# Altium schematic sheet P04_USB_hub.SchDoc
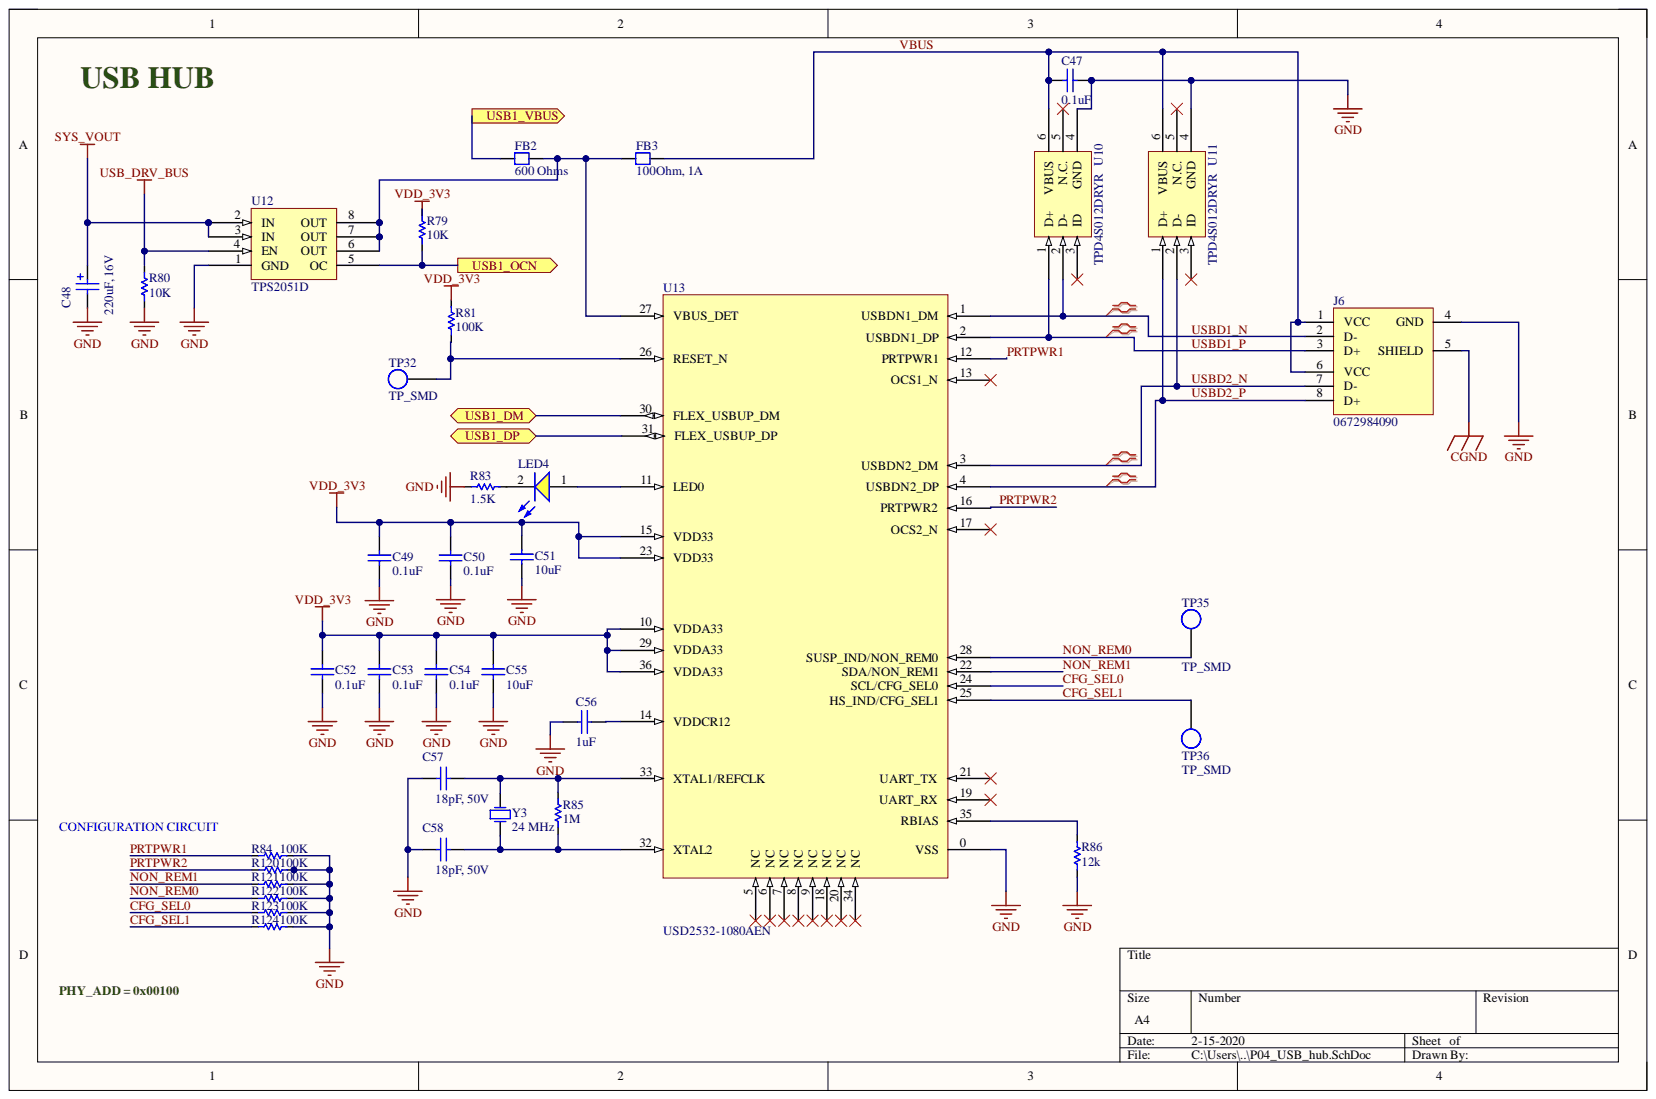
[diagram p0: P04_USB_hub.SchDoc]

## Parts
C47  0.1uF  [CAP_0603]
C48  220uF, 16V  [CAP_SMD_6.3x7.7]
C49  0.1uF  [CAP_0603]
C50  0.1uF  [CAP_0603]
C51  10uF  [CAP_0603]
C52  0.1uF  [CAP_0603]
C53  0.1uF  [CAP_0603]
C54  0.1uF  [CAP_0603]
C55  10uF  [CAP_0603]
C56  1uF  [CAP_0603]
C57  18pF, 50V  [CAP_0603]
C58  18pF, 50V  [CAP_0603]
FB2  600 Ohms  [CAP_0603]
FB3  100Ohm, 1A  [CAP_0603]
J6  0672984090
LED4  LTST-C191KSKT  [LED_0603]  (value not drawn)
R79  10K  [RES_0603]
R80  10K  [RES_0603]
R81  100K  [RES_0603]
R83  1.5K  [RES_0603]
R84  100K  [RES_0603]
R85  1M  [RES_0603]
R86  12k
R120  100K  [RES_0603]
R121  100K  [RES_0603]
R122  100K  [RES_0603]
R123  100K  [RES_0603]
R124  100K  [RES_0603]
TP32  TP_SMD  [TP_SMD]
TP35  TP_SMD  [TP_SMD]
TP36  TP_SMD  [TP_SMD]
U10  TPD4S012DRYR
U11  TPD4S012DRYR
U12  TPS2051D
U13  USD2532-1080AEN
Y3  24 MHz  [7A-24MHz]

## Ports
USB1_DM, USB1_DP, USB1_OCN, USB1_VBUS

## Nets
CGND: J6.5(SHIELD)
GND: C47.2 C48.1 C49.1 C50.1 C51.1 C52.1 C53.1 C54.1 C55.1 C56.1 C57.1 C58.1 J6.4(GND) R80.1 R83.1 R84.2 R86.1 R120.2 R121.2 R122.2 R123.2 R124.2 U10.4(GND) U11.4(GND) U12.1(GND) U13.0(VSS)
SYS_VOUT: C48.2 U12.2(IN) U12.3(IN)
USB_DRV_BUS: U12.4(EN)
VDD_3V3: C49.2 C50.2 C51.2 C52.2 C53.2 C54.2 C55.2 R79.2 R81.2 U13.10(VDDA33) U13.15(VDD33) U13.23(VDD33) U13.29(VDDA33) U13.36(VDDA33)
CFG_SEL0: R123.1 U13.24(SCL/CFG_SEL0)
CFG_SEL1: R124.1 TP36.0 U13.25(HS_IND/CFG_SEL1)
NON_REM0: R122.1 TP35.0 U13.28(SUSP_IND/NON_REM0)
NON_REM1: R121.1 U13.22(SDA/NON_REM1)
PRTPWR1: R84.1 U13.12(PRTPWR1)
PRTPWR2: R120.1 U13.16(PRTPWR2)
USB1_DM: U13.30(FLEX_USBUP_DM) ; port USB1_DM
USB1_DP: U13.31(FLEX_USBUP_DP) ; port USB1_DP
USB1_OCN: R79.1 U12.5(OC) ; port USB1_OCN
USB1_VBUS: FB2.1 ; port USB1_VBUS
USBD1_N: J6.2(D-) U10.2(D-) U13.1(USBDN1_DM)
USBD1_P: J6.3(D+) U10.1(D+) U13.2(USBDN1_DP)
USBD2_N: J6.7(D-) U11.2(D-) U13.3(USBDN2_DM)
USBD2_P: J6.8(D+) U11.1(D+) U13.4(USBDN2_DP)
VBUS: C47.1 FB3.2 J6.1(VCC) J6.6(VCC) U10.6(VBUS) U11.6(VBUS)
NetC56_2: C56.2 U13.14(VDDCR12)
NetC57_2: C57.2 R85.2 U13.33(XTAL1/REFCLK) Y3.2
NetC58_2: C58.2 R85.1 U13.32(XTAL2) Y3.1
NetFB2_2: FB2.2 FB3.1 U12.6(OUT) U12.7(OUT) U12.8(OUT) U13.27(VBUS_DET)
NetLED4_1: LED4.1 U13.11(LED0)
NetLED4_2: LED4.2 R83.2
NetR81_1: R81.1 TP32.0 U13.26(RESET_N)
NetR86_2: R86.2 U13.35(RBIAS)

Not connected: R80 2; U10 3(ID) 5(N.C.); U11 3(ID) 5(N.C.); U13 5(NC) 6(NC) 7(NC) 8(NC) 9(NC) 13(OCS1_N) 17(OCS2_N) 18(NC) 19(UART_RX) 20(NC) 21(UART_TX) 34(NC)

## Library data of the parts
C47 C49 C50 C52-C54 = C0603C104M4RACTU: CAP CER 0.1UF 16V X7R 0603; Manufacturer: KEMET; Tolerance: 20%; Ceramic Type: X7R; Component Kind: Standard; ComponentLink1Description: Manufacturer; ComponentLink1URL: http://www.we-online.de; ComponentLink2Description: Datasheet; ComponentLink2URL: https://content.kemet.com/datasheets/KEM_C1002_X7R_SMD.pdf; Datasheet: https://content.kemet.com/datasheets/KEM_C1002_X7R_SMD.pdf; Manufacturer Part No.: C0603C104M4RACTU; Mounting Technology: SMD; Package Description: 0603; Package Reference: CAP_0603; Rated Voltage: 16V; Supplier: Digi-Key; Supplier Part No.: 399-1099-1-ND; Value: 0.1uF; Library Name: OSD335-X_Devboard.SchLib
C48 = 865080345012: CAP 220 UF 20% 16 V; Manufacturer: Wurth Elektronik; Rated Voltage: 16 VDC; Component Kind: Standard; ComponentLink1Description: Manufacturer; ComponentLink1URL: http://www.we-online.de; ComponentLink2Description: Datasheet; ComponentLink2URL: https://katalog.we-online.com/pbs/datasheet/865080345012.pdf; Manufacturer Part No.: 865080345012; Mounting Technology: SMD; Package Description: CAP_SMD_6.3x7.7; Package Reference: CAP_SMD_6.3x7.7; Supplier: Digi-Key; Supplier Part No.: 732-8422-1-ND; Tolerance: 20%; Value: 220uF; Library Name: OSD335-X_Devboard.SchLib
C51 C55 = C0603C106M8PAC7867: CAP CER 10UF 10V X5R 0603; Manufacturer: KEMET; Ceramic Type: X7R; Tolerance: 20%; Component Kind: Standard; ComponentLink1Description: Manufacturer; ComponentLink1URL: http://www.we-online.de; ComponentLink2Description: Datasheet; ComponentLink2URL: https://content.kemet.com/datasheets/KEM_C1002_X7R_SMD.pdf; Datasheet: https://content.kemet.com/datasheets/KEM_C1002_X7R_SMD.pdf; Manufacturer Part No.: C0603C106M8PAC7867; Mounting Technology: SMD; Package Description: 0603; Package Reference: CAP_0603; Rated Voltage: 10V; Supplier: Digi-Key; Supplier Part No.: 399-14945-1-ND; Value: 10uF; Library Name: OSD335-X_Devboard.SchLib
C56 = C0603C105M4RACTU: CAP CER 1UF 16V X7R 0603; Manufacturer: KEMET; Tolerance: 20%; Ceramic Type: X7R; Component Kind: Standard; ComponentLink1Description: Manufacturer; ComponentLink1URL: http://www.we-online.de; ComponentLink2Description: Datasheet; ComponentLink2URL: https://content.kemet.com/datasheets/KEM_C1002_X7R_SMD.pdf; Datasheet: https://content.kemet.com/datasheets/KEM_C1002_X7R_SMD.pdf; Manufacturer Part No.: C0603C105M4RACTU; Mounting Technology: SMD; Package Description: 0603; Package Reference: CAP_0603; Rated Voltage: 16V; Supplier: Digi-Key; Supplier Part No.: 399-11136-1-ND; Value: 1uF; Library Name: OSD335-X_Devboard.SchLib
C57 C58 = C0603C180D5GACTU: CAP CER 18PF 50V C0G/NP0 0603 +/- 0.5 PF; Manufacturer: KEMET; Rated Voltage: 50 VDC; Tolerance: 0.5 pF; Component Kind: Standard; ComponentLink1Description: Manufacturer; ComponentLink1URL: http://www.we-online.de; ComponentLink2Description: Datasheet; ComponentLink2URL: https://katalog.we-online.com/pbs/datasheet/865080345012.pdf; Manufacturer Part No.: C0603C180D5GACTU; Mounting Technology: SMD; Package Description: 0603; Package Reference: CAP_0603; Supplier: Digi-Key; Supplier Part No.: 399-9018-1-ND; Value: 18 pF; Library Name: OSD335-X_Devboard.SchLib
FB2 = BBHV00160808601Y00: 600 Ohms @ 100MHz Ferrite Bead 0603 2A 100mOhm; Manufacturer: Chilisin Electronics; Component Kind: Standard; ComponentLink1Description: Manufacturer; ComponentLink1URL: http://www.we-online.de; ComponentLink2Description: Datasheet; ComponentLink2URL: https://content.kemet.com/datasheets/KEM_C1002_X7R_SMD.pdf; Datasheet: https://www.chilisin.com/upload/media/product/emi_bead/file/BBHV_Series.pdf; Manufacturer Part No.: BBHV00160808601Y00; Mounting Technology: SMD; Package Description: 0603; Package Reference: FB_0603; Rated Current: 2 A; Supplier: Digi-Key; Supplier Part No.: 2184-BBHV00160808601Y00CT-ND; Tolerance: -; Value: 600 Ohms; Library Name: Felix_Sch_lib.SchLib
FB3 = MPZ1608D101BTA00: FERRITE BEAD 100 OHM 0603 1LN; Manufacturer: TDK Corporation; Component Kind: Standard; Datasheet: https://product.tdk.com/info/en/catalog/datasheets/beads_commercial_power_mpz1608_en.pdf; Manufacturer Part No.: MPZ1608D101BTA00; Mounting Technology: SMD; Package Description: 100 Ohms @ 100MHz 1 Power Line Ferrite Bead 0603 (1608 Metric) 1A 150mOhm; Package Reference: CAP_0603; Supplier: Digi-Key; Supplier Part No.: 445-2988-1-ND; Library Name: OSD335-X_Devboard.SchLib
J6 = 0672984090: CONN RCPT TYPEA STACKED 8POS R/A; Manufacturer: Molex; ComponentLink1 Description: Manufacturer; ComponentLink2 Description: Datasheet; Component Kind: Standard; ComponentLink1URL: http://www.we-online.de; ComponentLink2URL: http://katalog.we-online.de/em/datasheet/629105150521.pdf; Datasheet: https://www.molex.com/pdm_docs/sd/672984090_sd.pdf; Manufacturer Part No.: 0672984090; Mounting Technology: THRU/SMD; Package Description: CONN RCPT TYPEA STACKED 8POS R/A; Package Reference: 0672984090; Supplier: Digikey; Supplier Part No.: WM17130-ND; Library Name: Felix_Sch_lib.SchLib
LED4 = LTST-C191KSKT: LED YELLOW CLEAR SMD 0603; Manufacturer: Lite-On Inc.; Test Current: 20 mA; Vf: 2.1V; Color: Yellow; Component Kind: Standard; ComponentLink1Description: Manufacturer; ComponentLink1URL: http://www.we-online.de; ComponentLink2Description: Datasheet; ComponentLink2URL: http://katalog.we-online.com/led/datasheet/150080BS75000.pdf; Datasheet: http://optoelectronics.liteon.com/upload/download/DS22-2000-224/LTST-C191KSKT.PDF; Manufacturer Part No.: LTST-C191KSKT; Mounting Technology: SMD; Package Reference: LED_0603; Supplier: Digi-Key; Supplier Part No.: 160-1448-1-ND; Library Name: Felix_Sch_lib.SchLib
R79 R80 = RC0603FR-0710KL: RES SMD 10K OHM 1% 1/10W 0603; Manufacturer: Yageo; Component Kind: Standard; ComponentLink1Description: Manufacturer Link; ComponentLink1URL: http://www.vishay.com/company/brands/dale/; ComponentLink2Description: Datasheet; ComponentLink2URL: http://www.vishay.com/docs/20035/dcrcwe3.pdf; Datasheet: https://www.yageo.com/upload/media/product/productsearch/datasheet/rchip/PYu-RC_Group_51_RoHS_L_10.pdf; Date: 2020; Manufacturer Part No.: RC0603FR-0710KL; Mounting Technology: SMD; Package Description: 0603; Package Reference: RES_0603; Power Rating: 1/10W; Supplier: Digikey; Supplier Part No.: 311-10.0KHRCT-ND; Tolerance: 1%; Value: 10K; Library Name: OSD335-X_Devboard.SchLib
R81 R84 R120-R124 = RC0603FR-07100KL: RES SMD 100K OHM 1% 1/10W 0603; Manufacturer: Yageo; Component Kind: Standard; ComponentLink1Description: Manufacturer Link; ComponentLink1URL: http://www.vishay.com/company/brands/dale/; ComponentLink2Description: Datasheet; ComponentLink2URL: http://www.vishay.com/docs/20035/dcrcwe3.pdf; Datasheet: https://www.yageo.com/upload/media/product/productsearch/datasheet/rchip/PYu-RC_Group_51_RoHS_L_10.pdf; Date: 2020; Manufacturer Part No.: RC0603FR-07100KL; Mounting Technology: SMD; Package Description: 0603; Package Reference: RES_0603; Power Rating: 1/10W; Supplier: Digikey; Supplier Part No.: 311-100KHRCT-ND; Tolerance: 1%; Value: 100K; Library Name: OSD335-X_Devboard.SchLib
R83 = RC0603FR-071K5L: RES SMD 1.5K OHM 1% 1/10W 0603; Manufacturer: Yageo; Component Kind: Standard; ComponentLink1Description: Manufacturer Link; ComponentLink1URL: http://www.vishay.com/company/brands/dale/; ComponentLink2Description: Datasheet; ComponentLink2URL: http://www.vishay.com/docs/20035/dcrcwe3.pdf; Datasheet: https://www.yageo.com/upload/media/product/productsearch/datasheet/rchip/PYu-RC_Group_51_RoHS_L_10.pdf; Date: 2020; Manufacturer Part No.: RC0603FR-071K5L; Mounting Technology: SMD; Package Description: 0603; Package Reference: RES_0603; Power Rating: 1/10W; Supplier: Digikey; Supplier Part No.: 311-1.50KHRCT-ND; Tolerance: 1%; Value: 1.5K; Library Name: OSD335-X_Devboard.SchLib
R85 = RC0603JR-071ML: RES SMD 1M OHM 5% 1/10W 0603; Manufacturer: Yageo; Component Kind: Standard; ComponentLink1Description: Manufacturer Link; ComponentLink1URL: http://www.vishay.com/company/brands/dale/; ComponentLink2Description: Datasheet; ComponentLink2URL: http://www.vishay.com/docs/20035/dcrcwe3.pdf; Datasheet: https://www.yageo.com/upload/media/product/productsearch/datasheet/rchip/PYu-RC_Group_51_RoHS_L_10.pdf; Date: 2020; Manufacturer Part No.: RC0603JR-071ML; Mounting Technology: SMD; Package Description: 0603; Package Reference: RES_0603; Power Rating: 1/10W; Supplier: Digikey; Supplier Part No.: 311-1.0MGRCT-ND; Tolerance: 5%; Value: 1M; Library Name: OSD335-X_Devboard.SchLib
R86 = RMCF0603FT12K0: RES 12K OHM 1% 1/10W 0603; Manufacturer: Stackpole Electronics Inc; Component Kind: Standard; ComponentLink1Description: Manufacturer Link; ComponentLink1URL: http://www.vishay.com/company/brands/dale/; ComponentLink2Description: Datasheet; ComponentLink2URL: http://www.vishay.com/docs/20035/dcrcwe3.pdf; Datasheet: https://www.seielect.com/catalog/sei-rmcf_rmcp.pdf; Date: 2020; Manufacturer Part No.: RMCF0603FT12K0; Mounting Technology: SMD; Package Description: 0603; Package Reference: RES_0603; Power Rating: 1/10W; Supplier: Digikey; Supplier Part No.: RMCF0603FT12K0CT-ND; Tolerance: 1%; Value: 12k; Library Name: Felix_Sch_lib.SchLib
U10 U11 = TPD4S012DRYR: TVS DIODE 5.5V 6SON; Manufacturer: Texas Instruments; Component Kind: Standard; ComponentLink1Description: Manufacturer Link; ComponentLink1URL: http://www.vishay.com/company/brands/dale/; ComponentLink2Description: Datasheet; ComponentLink2URL: http://www.vishay.com/docs/20035/dcrcwe3.pdf; Datasheet: http://www.ti.com/general/docs/suppproductinfo.tsp?distId=10&gotoUrl=http%3A%2F%2Fwww.ti.com%2Flit%2Fgpn%2Ftpd4s012; Manufacturer Part No.: TPD4S012DRYR; Mounting Technology: SMD; Package Description: SON; Package Reference: 6SON; Supplier: Digikey; Supplier Part No.: 296-24244-1-ND; Library Name: Felix_Sch_lib.SchLib
U12 = TPS2051D: IC PWR SWITCH N-CHAN 1:1 8SOIC; Manufacturer: Texas Instruments; Component Kind: Standard; ComponentLink1Description: Manufacturer Link; ComponentLink1URL: http://www.vishay.com/company/brands/dale/; ComponentLink2Description: Datasheet; ComponentLink2URL: http://www.vishay.com/docs/20035/dcrcwe3.pdf; Datasheet: http://www.ti.com/lit/pdf/slvs172; Manufacturer Part No.: TPS2051D; Mounting Technology: SMD; Package Description: SOIC; Package Reference: 8-SOIC; Supplier: Digikey; Supplier Part No.: 296-2576-5-ND; Library Name: Felix_Sch_lib.SchLib
U13 = USD2532-1080AEN: IC USB 2.0 HUB CTLR 2PORT 36SQFN; Manufacturer: Microchip Technology; Manufacturer Part No.: USB2532-1080AEN; Component Kind: Standard; ComponentLink1Description: Manufacturer Link; ComponentLink1URL: http://www.vishay.com/company/brands/dale/; ComponentLink2Description: Datasheet; ComponentLink2URL: http://www.vishay.com/docs/20035/dcrcwe3.pdf; Datasheet: http://www.microchip.com/mymicrochip/filehandler.aspx?ddocname=en567006; Mounting Technology: SMD; Package Description: QFN; Package Reference: 36SQFN; Supplier: Digikey; Supplier Part No.: USB2532-1080AEN-ND; Library Name: Felix_Sch_lib.SchLib
Y3 = 7A-24.000MAAJ-T: CRYSTAL 24.0000MHZ 18PF SMD; Manufacturer: TXC CORPORATION; Component Kind: Standard; ComponentLink1Description: Manufacturer Link; ComponentLink1URL: http://www.ecsxtal.com/; ComponentLink2Description: Data Sheet; Datasheet: CRYSTAL 24.0000MHZ 18PF SMD; Date: 2020; Manufacturer Part No.: 7A-24.000MAAJ-T; Mounting Technology: SMD; Package Description: 7A-24MHz; Package Reference: 7A-24MHz; Supplier: Digikey; Supplier Part No.: 887-1090-1-ND; Value: 24 MHz; Library Name: OSD335-X_Devboard.SchLib
TP32 TP35 TP36 = TP_SMD: Component Kind: Standard; Library Name: OSD335-X_Devboard.SchLib
